# Altium schematic sheet Z28 - LED Digit TH Board.SchDoc
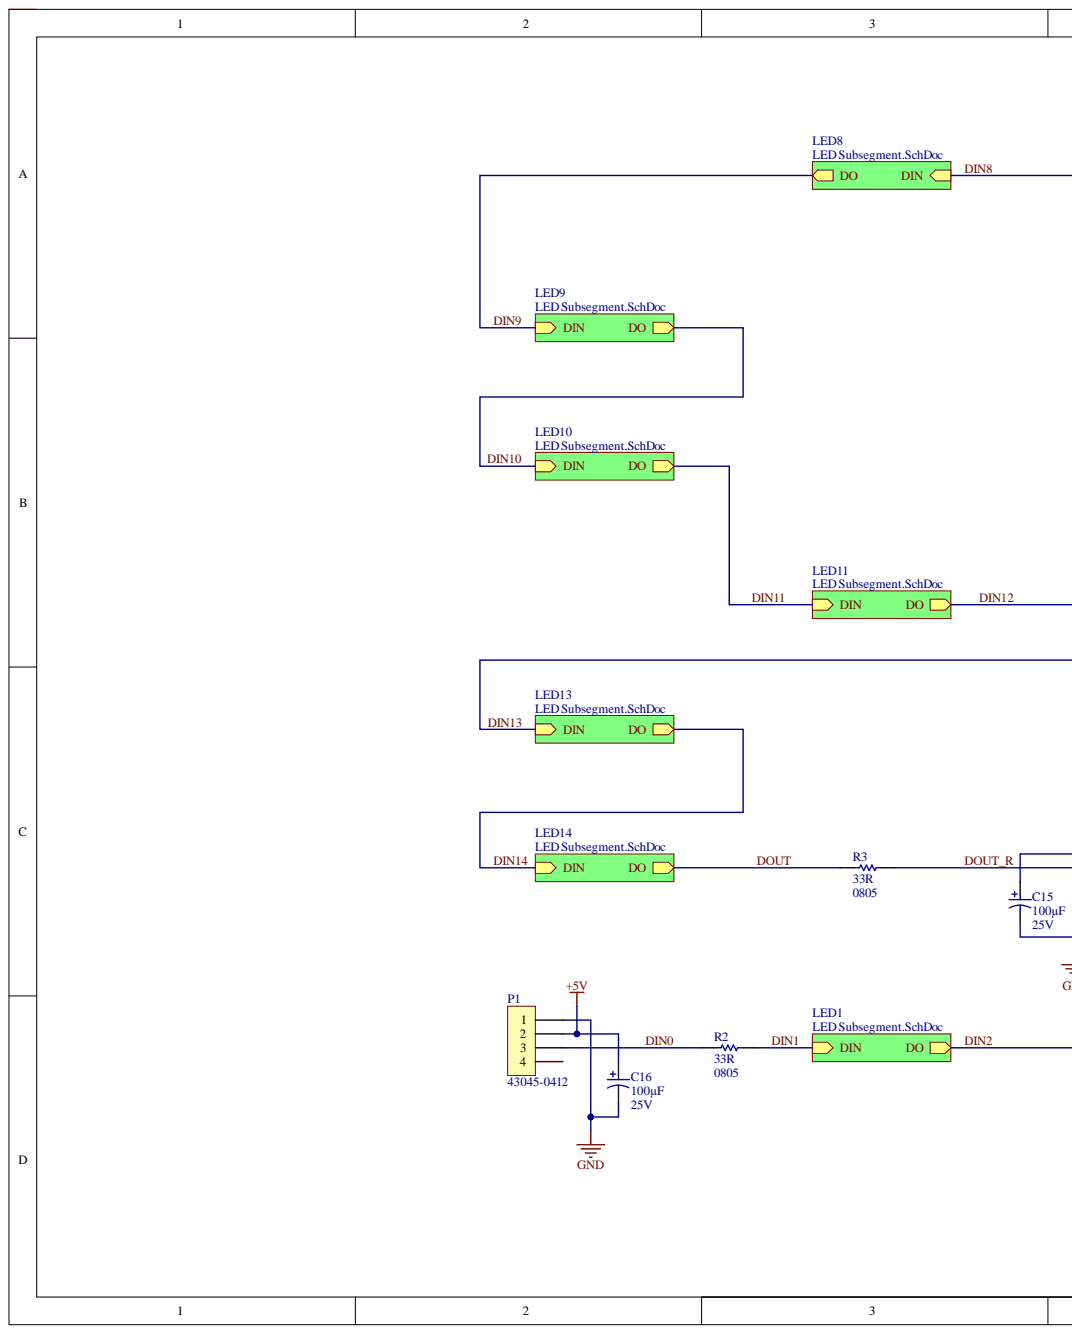
[diagram p0: Z28 - LED Digit TH Board.SchDoc, left]
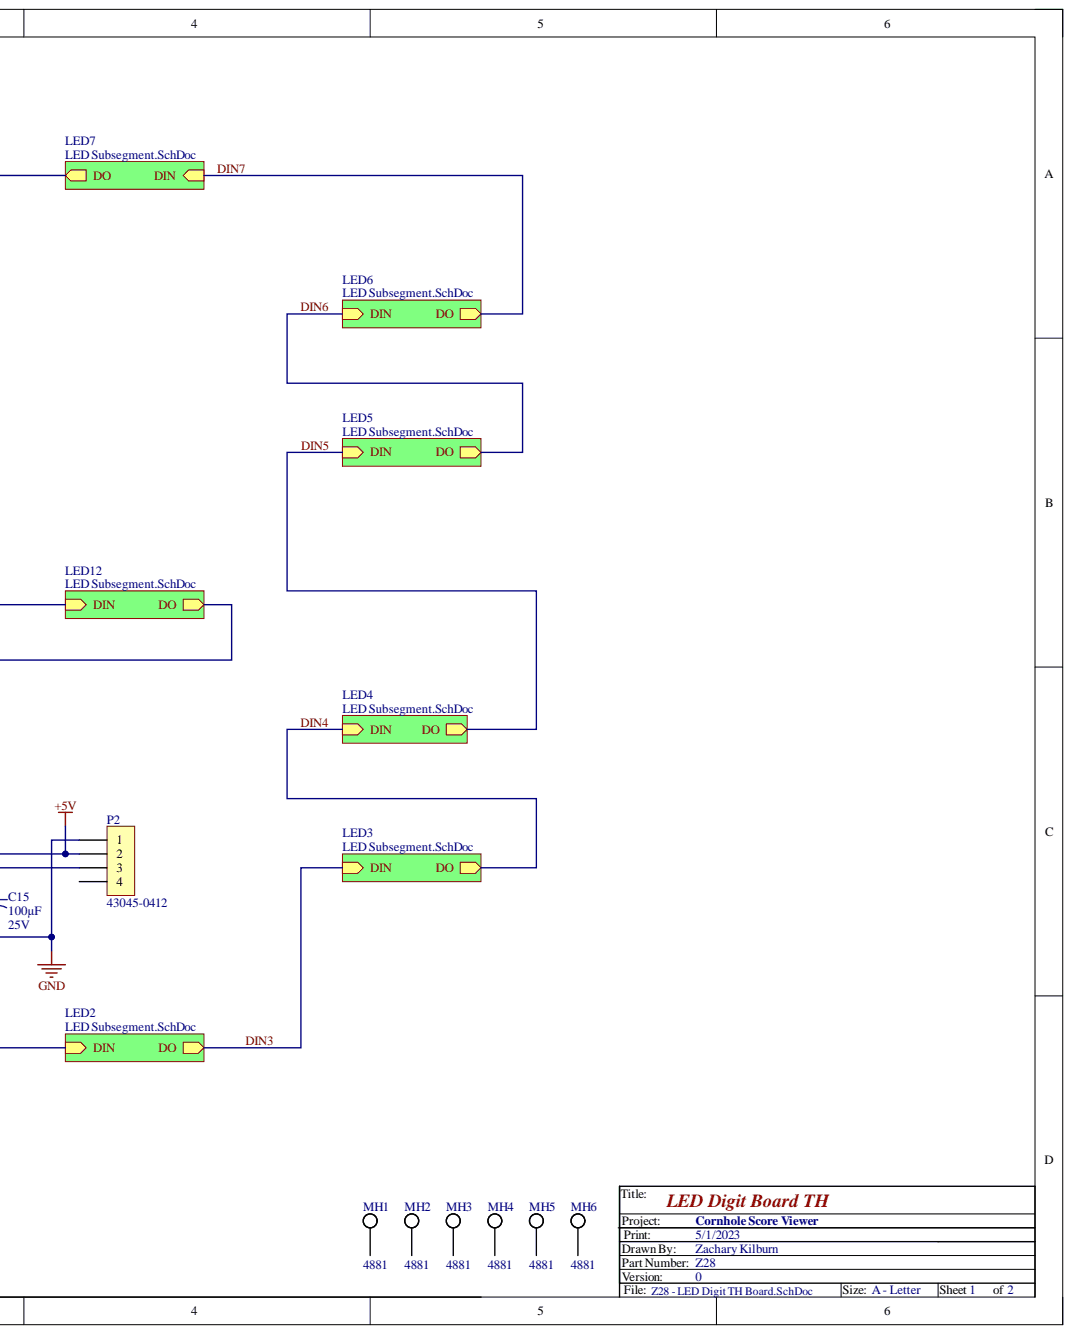
[diagram p1: Z28 - LED Digit TH Board.SchDoc, right]

## Parts
C15  value 100µF  Voltage: 25V  [PCC-PANA-CAP-ALUSMD-E-D8.0-H6.5-R]  (hidden comment: EEE-TG1E101UP)
C16  value 100µF  Voltage: 25V  [PCC-PANA-CAP-ALUSMD-E-D8.0-H6.5-R]  (hidden comment: EEE-TG1E101UP)
MH1  4881  [PCC-KEYE-4881-R]
MH2  4881  [PCC-KEYE-4881-R]
MH3  4881  [PCC-KEYE-4881-R]
MH4  4881  [PCC-KEYE-4881-R]
MH5  4881  [PCC-KEYE-4881-R]
MH6  4881  [PCC-KEYE-4881-R]
P1  43045-0412  [PCC-MOLX-43045-0412-R]
P2  43045-0412  [PCC-MOLX-43045-0412-R]
R2  PackageName: 0805  ValueText: 33R  [PCC-XXX-RES0805(2012)-N]  (hidden comment: 33R 1% 0805(2012))
R3  PackageName: 0805  ValueText: 33R  [PCC-XXX-RES0805(2012)-N]  (hidden comment: 33R 1% 0805(2012))

## Nets
+5V: C15.1 C16.1 P1.2 P2.2
GND: C15.2 C16.2 P1.1 P2.1
DIN0: P1.3 R2.2
DIN1: R2.1
DOUT: R3.2
DOUT_R: P2.3 R3.1
NetMH1_0: MH1.0
NetMH2_0: MH2.0
NetMH3_0: MH3.0
NetMH4_0: MH4.0
NetMH5_0: MH5.0
NetMH6_0: MH6.0
NetP1_4: P1.4
NetP2_4: P2.4
DIN2:
DIN3:
DIN4:
DIN5:
DIN6:
DIN7:
DIN8:
DIN9:
DIN10:
DIN11:
DIN12:
DIN13:
DIN14:

## Library data of the parts
C15 C16 = CMP-015090-0: Capacitor, Aluminum Electrolytic, 100uF, 25V, SMD, Size E; Manufacturer: Panasonic; Ripple Current: 100mA; Component Kind: Standard; ComponentLink1Description: Manufacturer URL; ComponentLink1URL: https://industrial.panasonic.com/; ComponentLink2Description: Product URL; ComponentLink2URL: https://industrial.panasonic.com/ww/products/pt/aluminum-cap-smd/models/EEETG1E101UP; ComponentLink3Description: Datasheet; ComponentLink3URL: https://industrial.panasonic.com/cdbs/www-data/pdf/RDE0000/ABA0000C1187.pdf; ComponentLink4Description: Package Specification; ComponentLink4URL: https://industrial.panasonic.com/cdbs/www-data/pdf/RDE0000/ABA0000C1187.pdf; HelpURL: https://industrial.panasonic.com/ww/products/pt/aluminum-cap-smd/models/EEETG1E101UP; Library Name: Aluminum Electrolytic Capacitors.IntLib; MountingTechnology: Surface Mount; OperatingTemperature: -40C to +125C; PackageName: E; PartNumber: EEE-TG1E101UP
MH1-MH6 = 4881: Spacer, Threaded, Solder, 1/16" Height, #4-40; Manufacturer: Keystone; Between Board Height: 1/16"; Component Kind: Standard; ComponentLink1Description: Manufacturer URL; ComponentLink1URL: https://www.keyelco.com/; ComponentLink2Description: Product URL; ComponentLink2URL: https://www.keyelco.com/product.cfm/product_id/14249; ComponentLink3Description: Datasheet URL; ComponentLink3URL: https://www.keyelco.com/product-pdf.cfm?p=14249; Part Number: 4881; Screw, Thread Size: #4-40; Supplier 1: Digi-Key; Supplier Part Number 1: 36-4881CT-ND; Library Name: Spacers-Standoffs.SchLib
P1 P2 = CMP-000027-0: Molex Micro-Fit 3.0 Connector, Dual-Row, 4-Position, Vertical, 3mm Pitch; Current: 5A; MatingStyle: Plug; Positions: 4; Rows: 2; Voltage: 250V; Component Kind: Standard; ComponentLink1Description: Manufacturer URL; ComponentLink1URL: http://www.molex.com; ComponentLink2Description: Product URL; ComponentLink2URL: http://www.molex.com/molex/products/datasheet.jsp?part=active/0430450412_PCB_HEADERS.xml; ComponentLink3Description: Datasheet; ComponentLink3URL: http://www.molex.com/pdm_docs/ps/PS-43045.pdf; ComponentLink4Description: Package Specification; ComponentLink4URL: http://www.molex.com/pdm_docs/sd/430450412_sd.pdf; HelpURL: http://www.molex.com/pdm_docs/sd/430450412_sd.pdf; Library Name: Molex-Micro-Fit.SchLib; Manufacturer: Molex; MountingTechnology: Through Hole; OperatingTemperature: -40C to +105C; Orientation: Vertical; PackageName: Micro-Fit 3.0; PartNumber: 43045-0412; Pitch: 3mm; RoHS: Yes; StorageTemperature: -40C to +105C
R2 R3 = CMP-003545-1: 33R 0.125W 1% 0805 (2012 Metric)  SMD; Power: 125mW; Value: 33; Component Kind: Standard; Library Name: Generic Resistors.SchLib; MountingTechnology: Surface Mount; Tolerence: 1%; Type: Standard
